# Altium schematic sheet USB-ESP32.SchDoc(USB-ESP32)
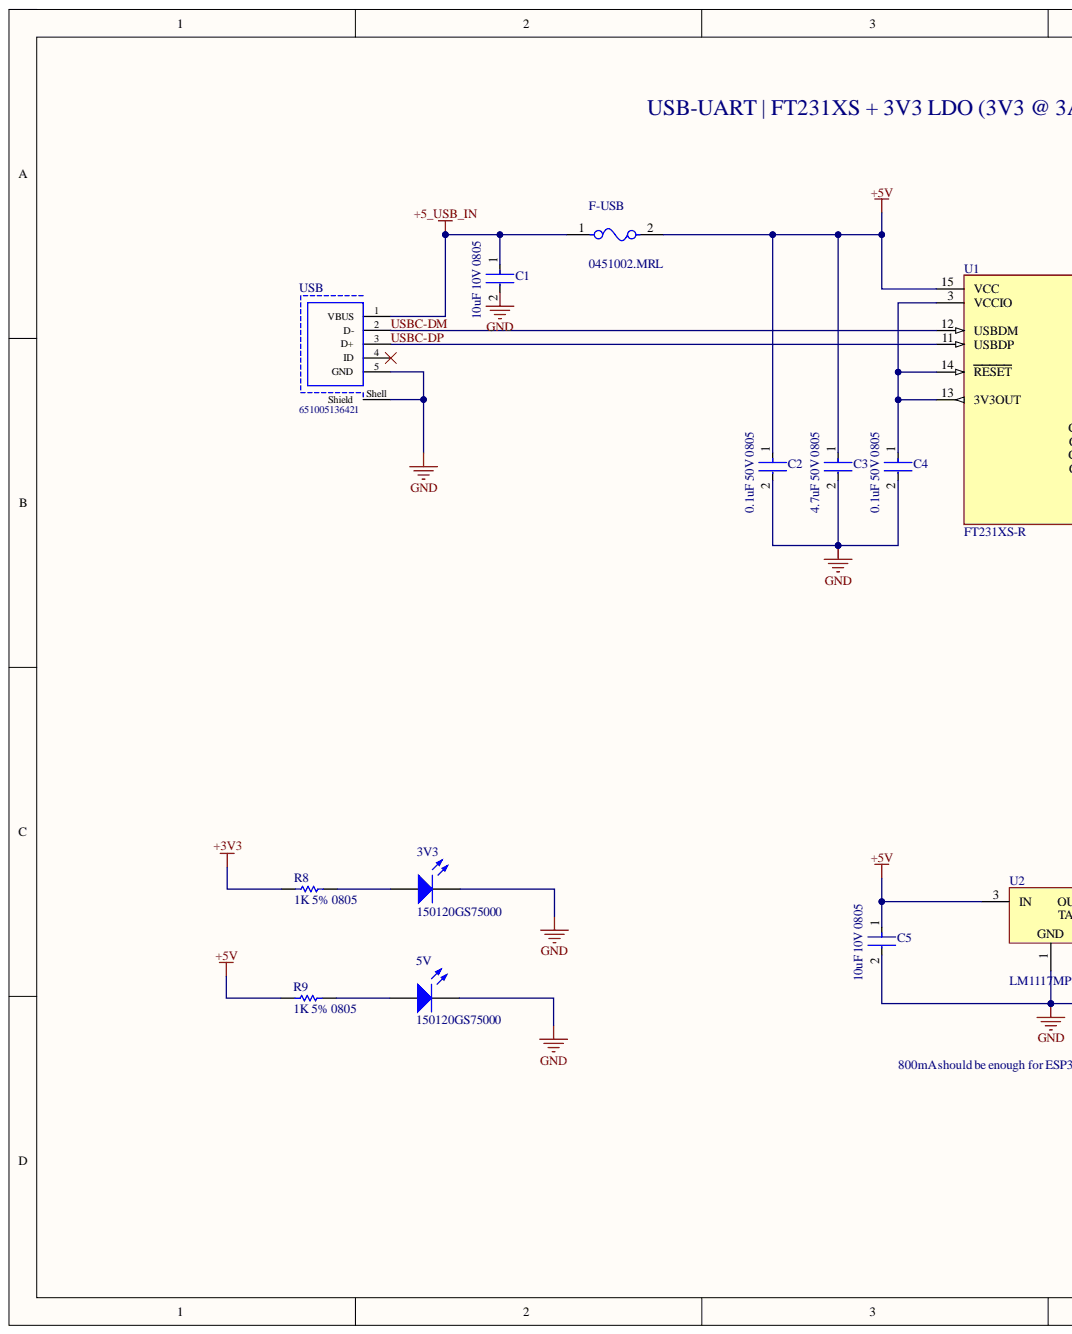
[diagram p0: USB-ESP32.SchDoc, left]
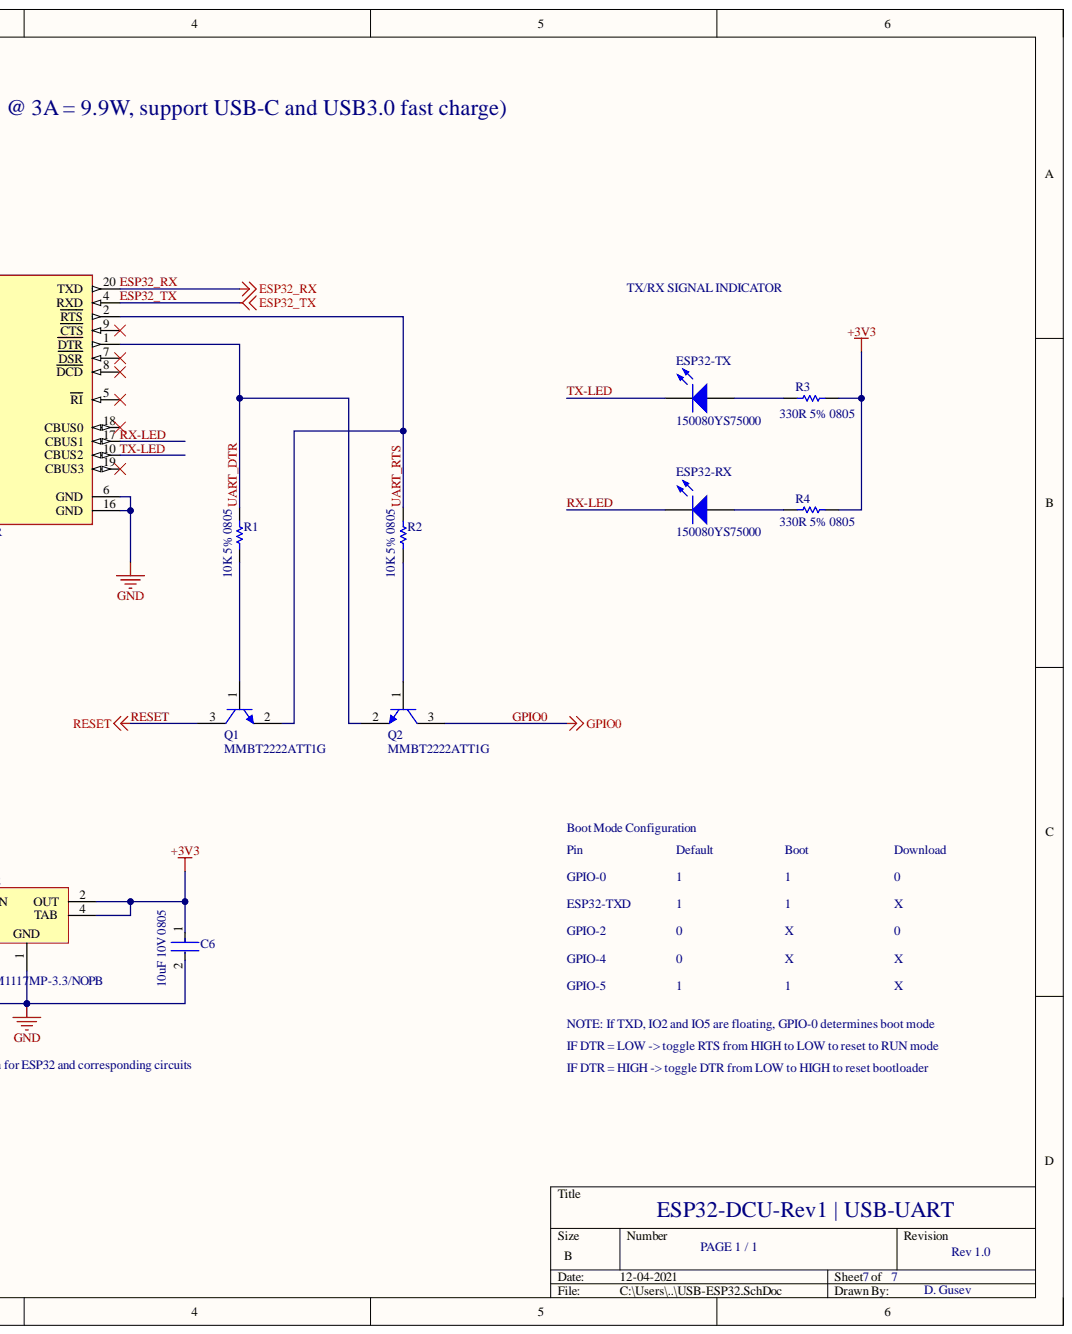
[diagram p1: USB-ESP32.SchDoc, right]

## Parts
3V3  150120GS75000  [1206_A]
5V  150120GS75000  [1206_A]
C1  10uF 10V 0805  value 10uF  Capacitance: 10uF  Voltage: 10V  [FP-C0805C-DG-MFG]
C2  0.1uF 50V 0805  [FP-C0805C-DN-MFG]
C3  4.7uF 50V 0805  value 4.7uF  Capacitance: 4.7uF  Voltage Rating: 50V  [FP-C2012-125-MFG]
C4  0.1uF 50V 0805  [FP-C0805C-DN-MFG]
C5  10uF 10V 0805  value 10uF  Capacitance: 10uF  Voltage: 10V  [FP-C0805C-DG-MFG]
C6  10uF 10V 0805  value 10uF  Capacitance: 10uF  Voltage: 10V  [FP-C0805C-DG-MFG]
ESP32-RX  150080YS75000  [0805_A]
ESP32-TX  150080YS75000  [0805_A]
F-USB  0451002.MRL  [FP-0451-MFG]
Q1  MMBT2222ATT1G  [ONSC-SC-75-3-463-01_V]
Q2  MMBT2222ATT1G  [ONSC-SC-75-3-463-01_V]
R1  10K 5% 0805  [RESC2013X60X40ML20T25]
R2  10K 5% 0805  [RESC2013X60X40ML20T25]
R3  330R 5% 0805  [RESC2013X60X40ML20T25]
R4  330R 5% 0805  [RESC2013X60X40ML20T25]
R8  1K 5% 0805  [RESC2013X60X40ML20T25]
R9  1K 5% 0805  [RESC2013X60X40ML20T25]
U1  FT231XS-R  [FP-SSOP-20-MFG]
U2  LM1117MP-3.3/NOPB  [DCY0004A_L]
USB  651005136421  [651005136421]

## Nets
+3V3: C6.1 R3.1 R4.1 R8.2 U2.2(OUT) U2.4(TAB)
+5_USB_IN: C1.1 F-USB.1 USB.1(VBUS)
+5V: C2.1 C3.1 C5.1 F-USB.2 R9.2 U1.15(VCC) U2.3(IN)
GND: 3V3.1 5V.1 C1.2 C2.2 C3.2 C4.2 C5.2 C6.2 U1.6(GND) U1.16(GND) U2.1 USB.5(GND) USB.Shell(Shield)
ESP32_RX: U1.20(TXD)
ESP32_TX: U1.4(RXD)
GPIO0: Q2.3
RESET: Q1.3
RX-LED: ESP32-RX.1 U1.17(CBUS1)
TX-LED: ESP32-TX.1 U1.10(CBUS2)
UART_DTR: Q2.2 R1.2 U1.1(DTR)
UART_RTS: Q1.2 R2.2 U1.2(RTS)
USBC-DM: U1.12(USBDM) USB.2(D-)
USBC-DP: U1.11(USBDP) USB.3(D+)
Net3V3_2: 3V3.2 R8.1
Net5V_2: 5V.2 R9.1
NetC4_1: C4.1 U1.3(VCCIO) U1.13(3V3OUT) U1.14(RESET)
NetESP32-RX_2: ESP32-RX.2 R4.2
NetESP32-TX_2: ESP32-TX.2 R3.2
NetQ1_1: Q1.1 R1.1
NetQ2_1: Q2.1 R2.1

Not connected: U1 5(RI) 7(DSR) 8(DCD) 9(CTS) 18(CBUS0) 19(CBUS3); USB 4(ID)

## Library data of the parts
3V3 5V = CMP-1426-00014-1: SMD mono-color Chip LED, WL-SMCW, Green; Manufacturer: Wuerth Elektronik; Color: Green; Component Kind: Standard; ComponentLink1Description: Manufacturer URL; ComponentLink1URL: http://www.we-online.com; ComponentLink2Description: Datasheet; ComponentLink2URL: http://katalog.we-online.com/led/datasheet/150120GS75000.pdf; Library Name: Altium Content Vault; PackageReference: 1206_A; PartNumber: 150120GS75000
C1 C5 C6 = CMP-2007-03243-2: CAP CER 10UF 10V X5R 0805; 3DS Part Number: 220-00001; Manufacturer: Kemet; Manufacturer Part Number: C0805C106K8PACTU; Material: Ceramic; Temperature Coefficient: 15%; Tolerance: 10%; Automotive: No; Category: Cap; Component Kind: Standard; ComponentLink2Description: Datasheet; ComponentLink2URL: https://content.kemet.com/datasheets/KEM_C1006_X5R_SMD.pdf; Device Class L1: Passive Components; Device Class L2: Capacitors; Device Class L3: Ceramic Capacitors; Digikey Description: CAP CER 10UF 10V X5R 0805; Height: 1.4mm; Ipc Land Pattern Name: CAPC200125X125; Lead Free: Yes; Library Name: Altium Content Vault; Mouser Description: CAP CER 10UF 10V X5R 0805; Octopart: https://octopart.com/c0805c106k8pactu-kemet-98697; Package: C0805C-DG; RoHS: TRUE; Temperature: -55°C to +85°C; Temperature Characteristic: X5R; Temperature Range High: +85°C; Temperature Range Low: -55°C; Voltage Rating: 10V
C2 C4 = CMP-2007-00279-2: CAP CER 0.1UF 50V X7R 0805; Manufacturer: KEMET; Manufacturer Part Number: C0805C104K5RACTU; Capacitance: 0.10 μF; Material: Ceramic; Temperature Coefficient: 30ppm/°C; Tolerance: 0.1; Value: 0.10 μF; Automotive: No; Category: Cap; Component Kind: Standard; ComponentLink2Description: Datasheet; ComponentLink2URL: https://content.kemet.com/datasheets/KEM_C1003_C0G_SMD.pdf; Device Class L1: Passive Components; Device Class L2: Capacitors; Device Class L3: Ceramic Capacitors; Digikey Description: CAP CER 0.1UF 50V X7R 0805; Height: 0.88mm; Ipc Land Pattern Name: CAPC200125X78; Lead Free: Yes; Library Name: Altium Content Vault; Mouser Description: Cap Ceramic 0.1uF 50V X7R 10% SMD 2.0 x 1.2 mm 125 C Paper T/R; Octopart: https://octopart.com/c0805c104k5ractu-kemet-143926; Package: C0805C-DN; RoHS: TRUE; Temperature: -55°C to +125°C; Temperature Characteristic: X7R; Temperature Range High: +125°C; Temperature Range Low: -55°C; Voltage Rating: 50 V
C3 = CMP-08246-000007-1: CAP CER 4.7UF 50V X5R 0805; Manufacturer: TDK Corporation; Manufacturer Part Number: C2012X5R1H475K125AB; Material: Ceramic; Temperature Coefficient: 15%; Tolerance: 10%; Automotive: No; Category: Cap; Component Kind: Standard; ComponentLink2Description: Datasheet; ComponentLink2URL: https://product.tdk.com/info/en/catalog/datasheets/mlcc_commercial_general_en.pdf; Device Class L1: Passive Components; Device Class L2: Capacitors; Device Class L3: Ceramic Capacitors; Digikey Description: CAP CER 4.7UF 50V X5R 0805; Height: 1.45mm; Lead Free: Yes; Library Name: Altium Content Vault; Mouser Description: Multilayer Ceramic Capacitors MLCC - SMD/SMT 0805 4.7uF 50volts X5R 10%; Octopart: https://octopart.com/c2012x5r1h475k125ab-tdk-25947720; Package: C2012-125; RoHS: TRUE; Temperature: -55°C to +85°C; Temperature Characteristic: X5R; Temperature Range High: +85°C; Temperature Range Low: -55°C
ESP32-RX ESP32-TX = CMP-1426-00012-1: SMD mono-color Chip LED, WL-SMCW, Yellow; Manufacturer: Wuerth Elektronik; Color: Yellow; Component Kind: Standard; ComponentLink1Description: Manufacturer URL; ComponentLink1URL: http://www.we-online.com; ComponentLink2Description: Datasheet; ComponentLink2URL: http://katalog.we-online.com/led/datasheet/150080YS75000.pdf; Library Name: Altium Content Vault; PackageReference: 0805_A; PartNumber: 150080YS75000
F-USB = CMP-08605-000053-1: FUSE BOARD MNT 2A 125VAC/VDC SMD; Manufacturer: Littelfuse; Fuse Blow Speed: Fast; Fuse I2T: 0.53A2Sec; Fuse Interrupt Ac Current: 50A; Max Fuse Ac Voltage: 125V; Resistance: 0.0367Ω; Value: 0.0367Ω; Automotive: No; Category: Fuse; Component Kind: Standard; ComponentLink2Description: Datasheet; ComponentLink2URL: https://www.littelfuse.com/~/media/electronics/datasheets/fuses/littelfuse_fuse_451_453_datasheet.pdf.pdf; Device Class L1: Circuit Protection; Device Class L2: Fuses; Device Class L3: unset; Digikey Description: FUSE BOARD MNT 2A 125VAC/VDC SMD; Fuse Current: 2A; Fuse Interrupt Dc Current: 50A; Height: 2.94mm; Lead Free: Yes; Library Name: Altium Content Vault; Manufacturer Part Number: 0451002.MRL; Max Fuse Dc Voltage: 125V; Mouser Description: Surface Mount Fuses 125V 2A; Octopart: https://octopart.com/0451002.mrl-littelfuse-566376; Package: 0451; RoHS: TRUE; Temperature: -55°C to +125°C; Temperature Range High: +125°C; Temperature Range Low: -55°C; Voltage Rating: 125V
Q1 Q2 = CMP-1048-00417-1: General Purpose Transistor, NPN Silicon, 3-Pin SC-75, Pb-Free, Tape and Reel; Manufacturer: On Semiconductor; fT Min (MHz): 300; IC Continuous (A): 0.6; Component Kind: Standard; ComponentLink1Description: Manufacturer URL; ComponentLink1URL: http://www.onsemi.com/; ComponentLink2Description: Datasheet; ComponentLink2URL: http://www.onsemi.cn/pub_link/Collateral/MMBT2222ATT1-D.PDF; ComponentLink3Description: Package Specification; ComponentLink3URL: http://www.onsemi.com/pub_link/Collateral/463-01.PDF; DatasheetVersion: Rev. 5; Library Name: Altium Content Vault; Mounting Technology: Surface Mount; PackageDescription: 3-Pin Small Outline Transistor, Body 1.6 x 0.8 mm, Pitch 0.5 mm; PackageReference: SC-75-3-463-01; PackageVersion: Rev. F, 07/2005; Packing: Tape and Reel; PartNumber: MMBT2222ATT1G; Polarity: NPN; RoHS: TRUE
R1-R4 R8 R9 = CMP-2001-00590-1: Manufacturer: Panasonic; Part Number: ERA6AEB123V; Component Kind: Standard; Library Name: Altium Content Vault
U1 = CMP-0248-00024-3: IC USB SERIAL FULL UART 20SSOP; Data Rate: 12Mbps; Max Supply Voltage: 5.5V; Min Supply Voltage: 2.97V; Number of Channels: 1; Operating Supply Current: 8mA; Operating Supply Voltage: 5V; Case/Package: SSOP; Component Kind: Standard; Height: 0.059inch; Interface: UART; Length: 8.74mm; Library Name: Altium Content Vault; Max Operating Temperature: 85°C; Min Operating Temperature: -40°C; Mount: Surface Mount; Number of Pins: 20; Package Quantity: 2000; Packaging: Tape and Reel; Radiation Hardening: No; Weight: 0.016oz; Width: 0.157inch
U2 = CMP-0062-01638-4: 800mA Low-Dropout Linear Regulator, 4-pin SOT-223, Pb-Free; Manufacturer: Texas Instruments; CompType: LDO IC; High-Reliability: Y; PackageTopMarking: XYTT*N05A; PowerWise: N; Code_IPC: SOT223-4; Code_JEDEC: TO-261-AA; Component Kind: Standard; ComponentLink1Description: Datasheet URL; ComponentLink1URL: http://www.ti.com/general/docs/lit/getliterature.tsp?genericPartNumber=LM1117-N&fileType=pdf; Library Name: Altium Content Vault; PackageDescription: Molded SOT-223, 6.50 x 3.56 x 1.6mm Body, 4 Lead, 2.3mm Pitch; PackageReference: DCY0004A; PartNumber: LM1117MP-3.3/NOPB; PinCount: 4; RoHS: Y
USB = CMP-1502-03248-1: Mini USB 2.0 Type B Receptacle WR-COM, Vertical, THT; Manufacturer: Wurth Elektronik; Contact Resistance: 20mOhm; Rated Current: 1A; Working Voltage: 30VAC; Component Kind: Standard; Connector Type: MiniUSB2.0TypeB; Datasheet URL: https://katalog.we-online.de/em/datasheet/651005136421%282%29.pdf; Gender: Receptacle; Library Name: Altium Content Vault; Manufacturer URL: http://www.we-online.de; Mounting Technology: THT; Operating Temperature: -40to105degC; Orientation: Vertical; Package Reference: 651005136421; Packaging: Tray; Part Number: 651005136421; Publisher: WurthElektronik
